# Altium schematic sheet power.SchDoc(U_power)
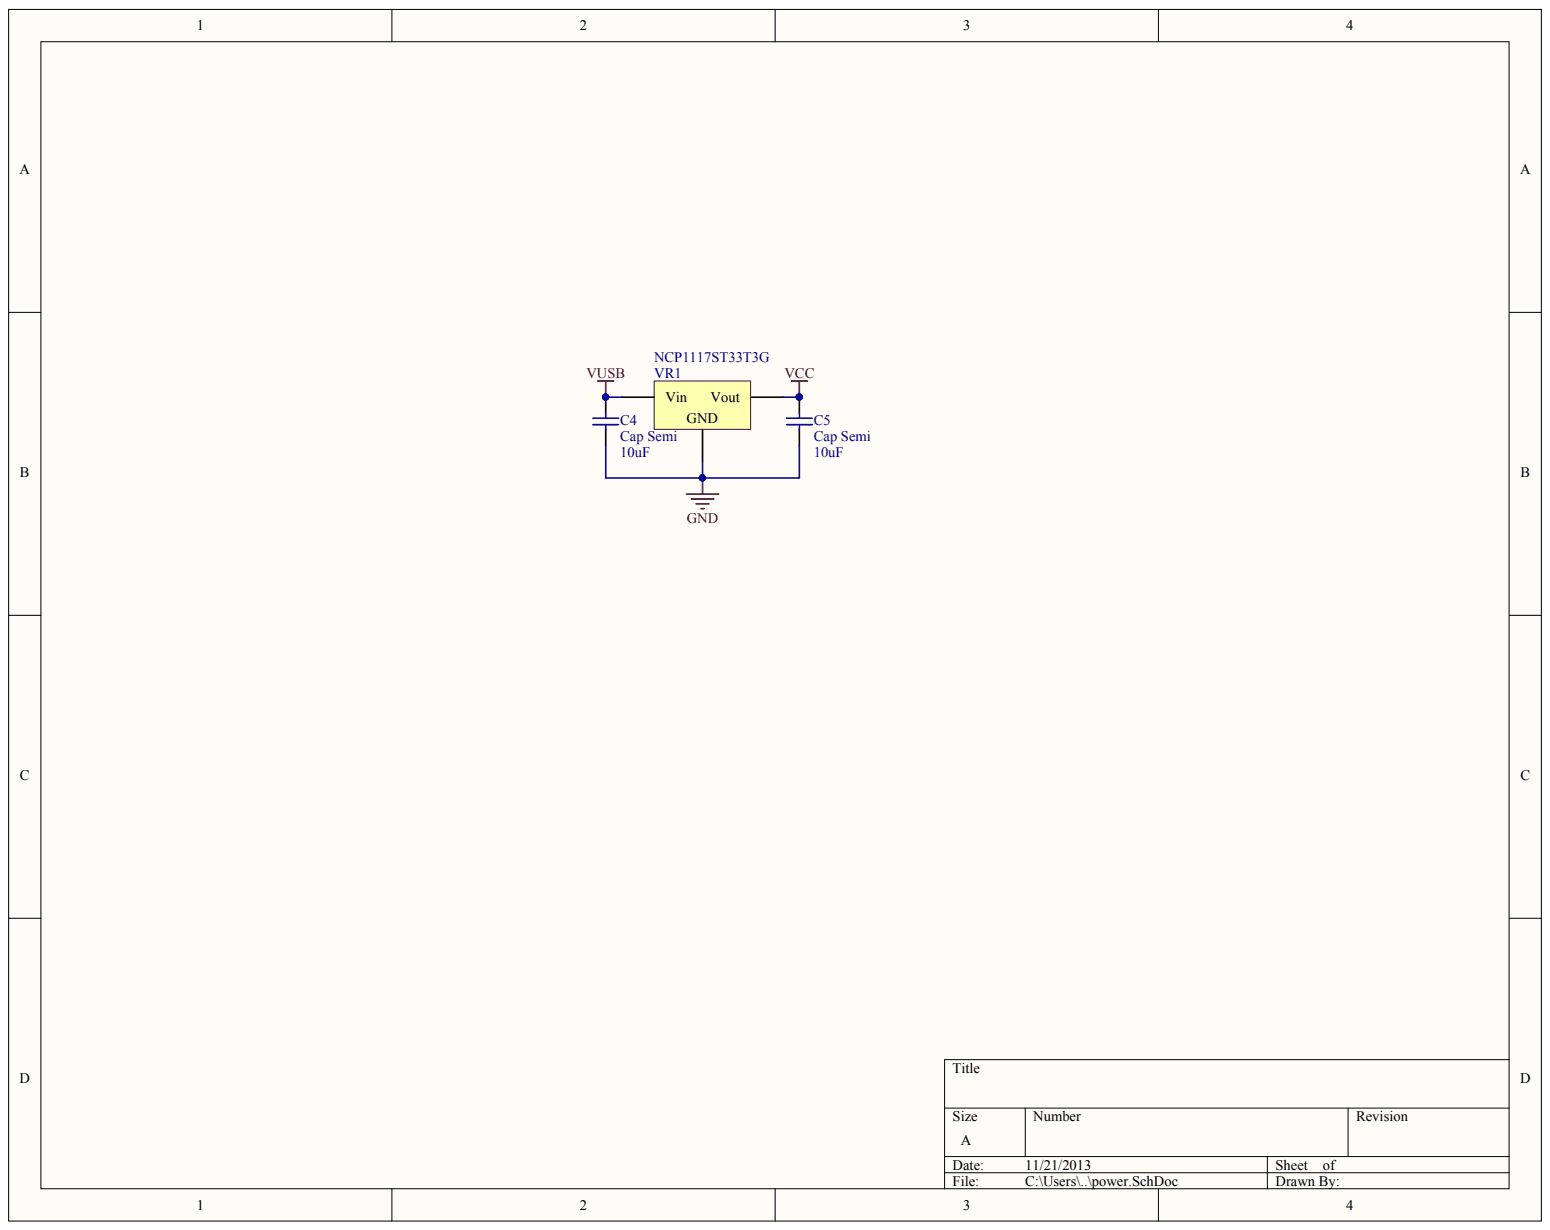
[diagram p0: power.SchDoc]

## Parts
C4  Cap Semi  value 10uF
C5  Cap Semi  value 10uF
VR1  NCP1117ST33T3G

## Nets
GND: C4.1 C5.1 VR1.2
VCC: C5.2 VR1.3
VUSB: C4.2 VR1.1

## Library data of the parts
C4 C5 VR1 = (no symbol name): LatestRevisionDate: 29-May-2009; LatestRevisionNote: IPC-7351 Footprint Added.; Publisher: Altium Limited
  C4 C5: Published: 8-Jun-2000; PackageReference: C1206; PackageDescription: Chip Capacitor
  VR1: Published: 24-Mar-1999; PackageReference: D2PAK; PackageDescription: 3-Pin Transistor Outline Package 2.54 mm Pitch, 15.1 mm Lead Span
